# Altium schematic sheet PAGE4 - SFP.SchDoc
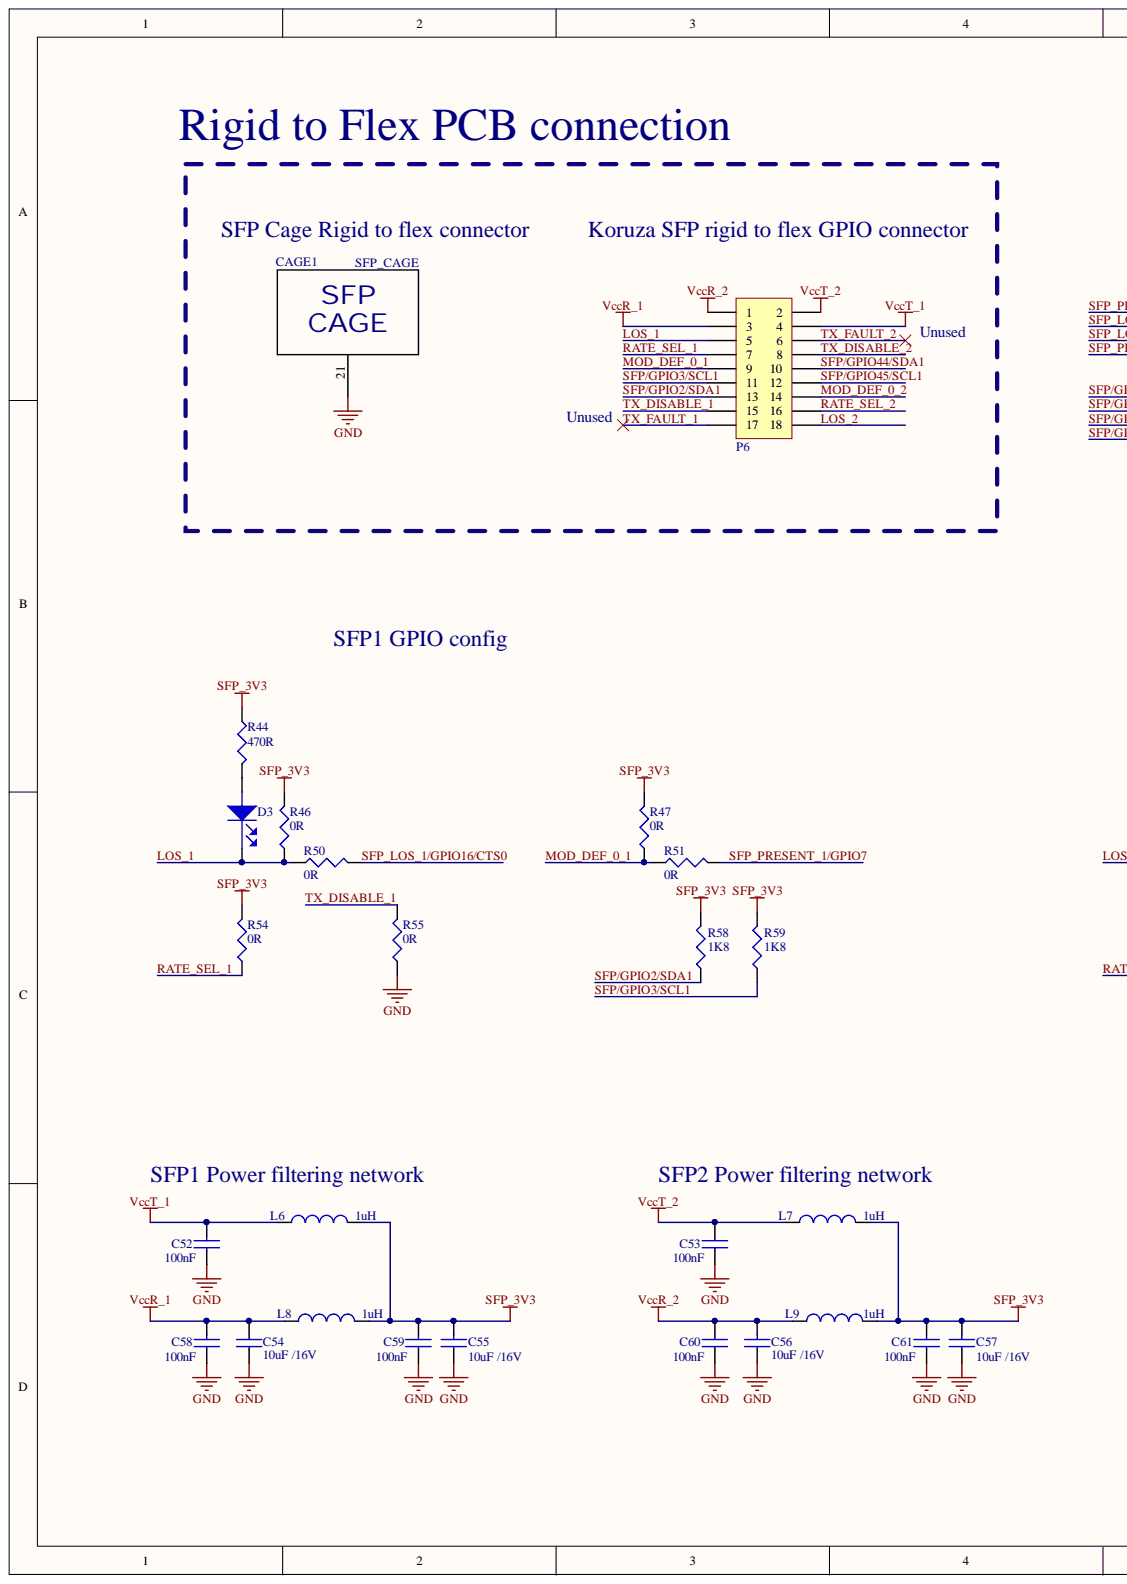
[diagram p0: PAGE4 - SFP.SchDoc, left]
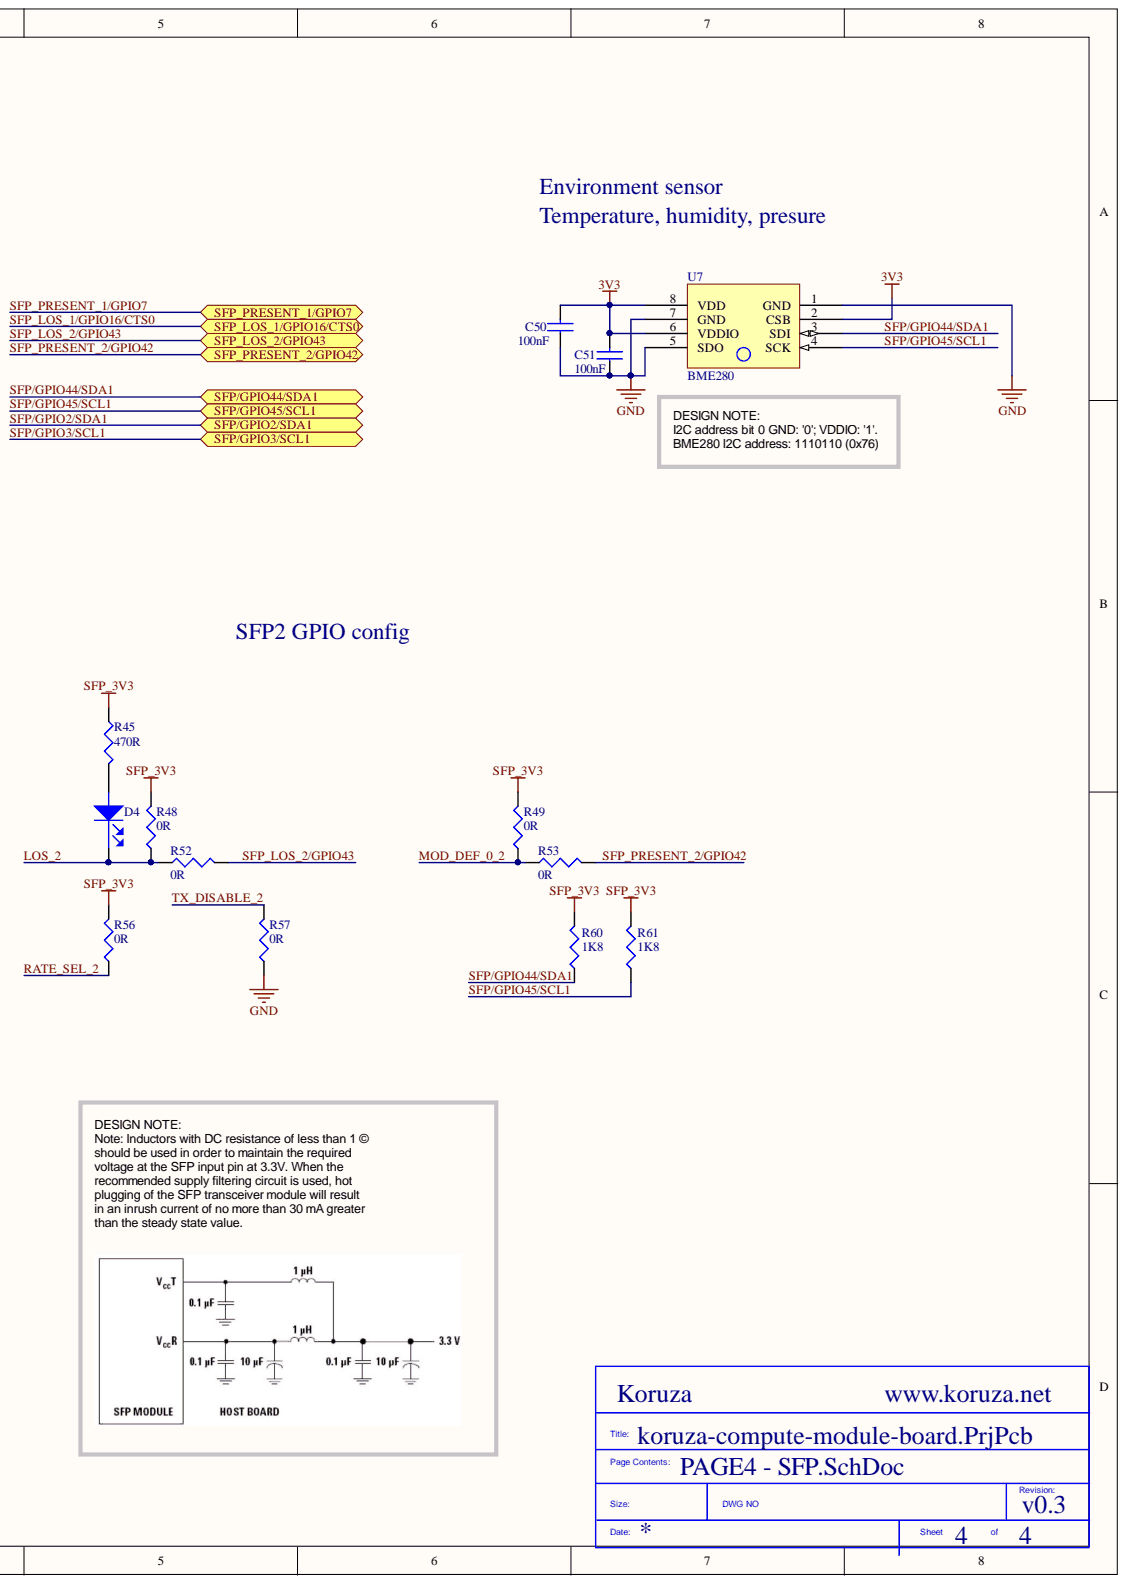
[diagram p1: PAGE4 - SFP.SchDoc, right]

## Parts
C50  100nF  [CAP0603]
C51  100nF  [CAP0603]
C52  100nF  [CAP0603]
C53  100nF  [CAP0603]
C54  10uF /16V  [CAP0603]
C55  10uF /16V  [CAP0603]
C56  10uF /16V  [CAP0603]
C57  10uF /16V  [CAP0603]
C58  100nF  [CAP0603]
C59  100nF  [CAP0603]
C60  100nF  [CAP0603]
C61  100nF  [CAP0603]
CAGE1  SFP_CAGE  Connector Type: SFP  [PCB_SFP_CAGE_FOOTPRINT]
D3  LED RED  [LED0603]  (value not drawn)
D4  LED RED  [LED0603]  (value not drawn)
L6  1uH  [CAP0805]
L7  1uH  [CAP0805]
L8  1uH  [CAP0805]
L9  1uH  [CAP0805]
P6  HED2X9  [HDR2X9]  (value not drawn)
R44  470R  [RES0603]
R45  470R  [RES0603]
R46  0R  [RES0603]
R47  0R  [RES0603]
R48  0R  [RES0603]
R49  0R  [RES0603]
R50  0R  [RES0603]
R51  0R  [RES0603]
R52  0R  [RES0603]
R53  0R  [RES0603]
R54  0R  [RES0603]
R55  0R  [RES0603]
R56  0R  [RES0603]
R57  0R  [RES0603]
R58  1K8  [RES0603]
R59  1K8  [RES0603]
R60  1K8  [RES0603]
R61  1K8  [RES0603]
U7  BME280  [CAVITY MEMS]

## Ports
SFP/GPIO2/SDA1, SFP/GPIO3/SCL1, SFP/GPIO44/SDA1, SFP/GPIO45/SCL1, SFP_LOS_1/GPIO16/CTS0, SFP_LOS_2/GPIO43, SFP_PRESENT_1/GPIO7, SFP_PRESENT_2/GPIO42

## Nets
3V3: C50.2 C51.2 U7.2(CSB) U7.6(VDDIO) U7.8(VDD)
GND: C50.1 C51.1 C52.1 C53.1 C54.1 C55.1 C56.1 C57.1 C58.1 C59.1 C60.1 C61.1 CAGE1.21 R55.1 R57.1 U7.1(GND) U7.5(SDO) U7.7(GND)
SFP_3V3: C55.2 C57.2 C59.2 C61.2 L6.2 L7.2 L8.2 L9.2 R44.1 R45.1 R46.2 R47.2 R48.2 R49.2 R54.2 R56.2 R58.2 R59.2 R60.2 R61.2
VCCR_1: C54.2 C58.2 L8.1 P6.3
VCCR_2: C56.2 C60.2 L9.1 P6.1
VCCT_1: C52.2 L6.1 P6.4
VCCT_2: C53.2 L7.1 P6.2
LOS_1: D3.1 P6.5 R46.1 R50.1
LOS_2: D4.1 P6.18 R48.1 R52.1
MOD_DEF_0_1: P6.9 R47.1 R51.1
MOD_DEF_0_2: P6.14 R49.1 R53.1
RATE_SEL_1: P6.7 R54.1
RATE_SEL_2: P6.16 R56.1
SFP/GPIO2/SDA1: P6.13 R58.1 ; port SFP/GPIO2/SDA1
SFP/GPIO3/SCL1: P6.11 R59.1 ; port SFP/GPIO3/SCL1
SFP/GPIO44/SDA1: P6.10 R60.1 U7.3(SDI) ; port SFP/GPIO44/SDA1
SFP/GPIO45/SCL1: P6.12 R61.1 U7.4(SCK) ; port SFP/GPIO45/SCL1
SFP_LOS_1/GPIO16/CTS0: R50.2 ; port SFP_LOS_1/GPIO16/CTS0
SFP_LOS_2/GPIO43: R52.2 ; port SFP_LOS_2/GPIO43
SFP_PRESENT_1/GPIO7: R51.2 ; port SFP_PRESENT_1/GPIO7
SFP_PRESENT_2/GPIO42: R53.2 ; port SFP_PRESENT_2/GPIO42
TX_DISABLE_1: P6.15 R55.2
TX_DISABLE_2: P6.8 R57.2
TX_FAULT_1: P6.17
TX_FAULT_2: P6.6
NetD3_2: D3.2 R44.2
NetD4_2: D4.2 R45.2

## Library data of the parts
C50-C53 C58-C61 = 100nF: MURATA - GRM188R71C104KA01J - CAP, MLCC, X7R, 0.1UF, 16V, 0603; Capacitance: 0.1; Capacitance Tolerance: ± 10%; Ceramic Capacitor Case: 0603 [1608 Metric]; Dielectric Characteristic: X7; Product Range: GRM Series; SVHC: No SVHC (20-Jun-2016; Voltage Rating: 16; Automotive Qualification Standard: -; Component Kind: Standard; ComponentLink1Description: http://www.farnell.com/datasheets/2189124.pdf; ComponentLink1URL: http://www.farnell.com/datasheets/2189124.pdf; Library Name: SCHLib.SchLib; Manufacturer: MURATA; Manufacturer Part Number: GRM188R71C104KA01J; MSL: MSL 1 - Unlimited; Operating Temperature Max: 125; Operating Temperature Min: -55; Packaging: Cut Tape; Pricing 1: 10=0.0145, 100=0.0126, 500=0.0081, 2500=0.0059, 5000=0.0052, 10000=0.0046, 50000=0.0036, 100000=0.0035 (GBP); RoHS: YES; Stock 1: 18297; Supplier 1: Farnell; Supplier Part Number 1: 2688519
C54-C57 = GRM188R61C106MA73D: MURATA - GRM188R61C106MA73D - CAP, MLCC, X5R, 10UF, 16V, 0603; Capacitance: 10; Capacitance Tolerance: ± 20%; Ceramic Capacitor Case: 0603 [1608 Metric]; Dielectric Characteristic: X5; Product Range: GRM Series; SVHC: No SVHC (17-Dec-2015)<!--FANDB--><div class="features-and-benefits-container"><div class="features-and-benefits-description"><span class="features-benefits-value">The Murata GRM series capacitors are Multilayer Ceramic Chip Capacitors (MLCC) used for general purpose applications. These MLCC capacitors are classified into temperature compensating and high dielectric constant types depending on the change in electrostatic capacitance due to change in temperature. The temperature compensating capacitors feature a small rate of change in electrostatic capacitance as the temperature changes and are used for applications such as filters and high frequency circuit matching. The high dielectric constant capacitors use materials with a high dielectric constant and feature a large electrostatic capacitance. Typical applications are power supply decoupling and smoothing circuits.</span></div><div class="features-and-benefits-list"><ul class="features-and-benefits-list-list"><li class="features-and-benefits-list-item">Large capacity and small size in a multilayer structure</li><li class="features-and-benefits-list-item">Capacitance value ranging from 0.1pF to 220?F</li><li class="features-and-benefits-list-item">Tin plated external electrodes, excellent solderability</li><li class="features-and-benefits-list-item">Provides good high frequency characteristics</li><li class="features-and-benefits-list-item">Excellent pulse response</li><li class="features-and-benefits-list-item">High reliability</li><li class="features-and-benefits-list-item">Capacitor size varies from 0.4×0.2mm to 5.7×5.0mm</li><li class="features-and-benefits-list-item">Rated voltage of 2.5VDC to 3.15; Voltage Rating: 16; Automotive Qualification Standard: -; Component Kind: Standard; ComponentLink1Description: http://www.farnell.com/datasheets/2048226.pdf; ComponentLink1URL: http://www.farnell.com/datasheets/2048226.pdf; Library Name: SCHLib.SchLib; Manufacturer: MURATA; Manufacturer Part Number: GRM188R61C106MA73D; MSL: -; Operating Temperature Max: 85; Operating Temperature Min: -55; Packaging: Cut Tape; Pricing 1: 10=0.181, 100=0.103, 500=0.0863, 1000=0.0778, 2000=0.0677 (GBP); RoHS: YES; Stock 1: 21735; Supplier 1: Farnell; Supplier Part Number 1: 2426958
CAGE1 = SFP_CAGE_FOOTPRINT: TE CONNECTIVITY - 2007194-1 - CAGE, 1X1, SFP+, PCI APPLICATIONS; Accessory Type: Connector Cage / Frame; Connector Mounting: PCB Mount; Connector Mounting Orientation: PC Board; Contact Termination: Solder; For Use With: Small Form-Factor Pluggable Connectors; Component Kind: Standard; ComponentLink1Description: http://www.farnell.com/datasheets/1654545.pdf; ComponentLink1URL: http://www.farnell.com/datasheets/1654545.pdf; ComponentLink2Description: http://www.farnell.com/cad/1661409.pdf; ComponentLink2URL: http://www.farnell.com/cad/1661409.pdf; ComponentLink3Description: http://www.farnell.com/datasheets/1922296.pdf; ComponentLink3URL: http://www.farnell.com/datasheets/1922296.pdf; Library Name: SCHLib.SchLib; Manufacturer: TE CONNECTIVITY; Manufacturer Part Number: 2007194-1; Material: Nickel Silver<!--FANDB--><div class="features-and-benefits-container"><div class="features-and-benefits-description"><span class="features-benefits-value">The 2007194-1 is a 1-port Cage Assembly with external springs and solder through-hole termination. It is made with nickel silver single cage. The SFP+ pluggable cage designed to support data rate of 16Gbps. This SFP+ pluggable cage has one degree mounting angle to meet the requirements of SFF-8075 for PCI card applications and support belly-to-belly mount applications.</span></div><div class="features-and-benefits-applications"><ul class="features-and-benefits-value"><li class="features-and-benefits-list-item">Industrial</li></ul></div></div>; Pricing 1: 1=4.6, 5=4.41, 10=4.22, 25=4.03, 50=3.5, 200=3.18, 500=2.86, 2000=2.8 (GBP); Product Range: -; RoHS: YES; Stock 1: 26; Supplier 1: Farnell; Supplier Part Number 1: 1755779
D3 D4 = HSMS-C190: BROADCOM LIMITED - HSMS-C190 - LED, RED, 10MCD, 626NM; Forward Current If: 20; Forward Voltage: 2.1; LED Mounting: SMD; Lens Shape: Rectangular; Luminous Intensity: 10; SVHC: No SVHC (17-Dec-2015)<!--FANDB--><div class="features-and-benefits-container"><div class="features-and-benefits-description"><span class="features-benefits-value">The HSMS-C190 is a HER SMD Chip LED with untinted/diffused lens, made of GaP. It is designed in an industry standard package 1.6 x 0.8mm footprint with 0.8mm profile. It is compatible with IR reflow solder processes. The small size and wide viewing angle make this LED prime choices for backlighting applications and front panel illumination especially where space is a premium.</span></div><div class="features-and-benefits-list"><ul class="features-and-benefits-list-list"><li class="features-and-benefits-list-item">Small size</li><li class="features-and-benefits-list-item">Industry standard footprint</li><li class="features-and-benefits-list-item">Compatible with IR solder</li><li class="features-and-benefits-list-item">Diffused optics</li><li class="features-and-benefits-list-item">-40 to 85; Viewing Angle: 170°; Wavelength Typ: 626; Automotive Qualification Standard: -; Bulb Size: -; Component Kind: Standard; ComponentLink1Description: http://www.farnell.com/datasheets/313031.pdf; ComponentLink1URL: http://www.farnell.com/datasheets/313031.pdf; ComponentLink2Description: http://www.farnell.com/datasheets/1681524.pdf; ComponentLink2URL: http://www.farnell.com/datasheets/1681524.pdf; ComponentLink3Description: http://www.farnell.com/datasheets/2165483.pdf; ComponentLink3URL: http://www.farnell.com/datasheets/2165483.pdf; LED Colour: Red; Library Name: SCHLib.SchLib; Manufacturer: BROADCOM LIMITED; Manufacturer Part Number: HSMS-C190; MSL: -; Packaging: Cut Tape; Pricing 1: 1=0.361, 10=0.256, 50=0.235, 100=0.106, 200=0.101 (GBP); Product Range: -; RoHS: YES; Stock 1: 7534; Supplier 1: Farnell; Supplier Part Number 1: 2497356
L6-L9 = 74479773210: WURTH ELEKTRONIK - 74479773210 - INDUCTOR, MULTILAYER, 1UH, SMD; DC Resistance Max: 0.18; Inductance: 1; Inductance Tolerance: ± 20%; Power Inductor Case: 2mm x 1.25mm x 0.5; Product Range: WE-PMI Series; RMS Current (Irms): 1.2; Saturation Current (Isat): 800; Component Kind: Standard; ComponentLink1Description: http://www.farnell.com/cad/2130715.pdf; ComponentLink1URL: http://www.farnell.com/cad/2130715.pdf; ComponentLink2Description: http://www.farnell.com/datasheets/1795751.pdf; ComponentLink2URL: http://www.farnell.com/datasheets/1795751.pdf; Inductor Construction: Multilayer; Library Name: SCHLib.SchLib; Manufacturer: WURTH ELEKTRONIK; Manufacturer Part Number: 74479773210; Packaging: Cut Tape; Pricing 1: 1=0.39, 50=0.34, 100=0.29, 250=0.25 (GBP); RoHS: YES; Stock 1: 46; Supplier 1: Farnell; Supplier Part Number 1: 2400015
P6 = MHDR2X9: Header, 9-Pin, Dual row; Component Kind: Standard; LatestRevisionDate: 17-Jul-2002; LatestRevisionNote: Re-released for DXP Platform.; Library Name: SCHLib.SchLib; Publisher: Altium Limited
R44 R45 = MCMR06X471 JTL: MULTICOMP - MCMR06X471 JTL - RES, CERAMIC, 470R, 5%, 0.1W, 0603; Component Kind: Standard; ComponentLink1Description: http://www.farnell.com/datasheets/1716707.pdf; ComponentLink1URL: http://www.farnell.com/datasheets/1716707.pdf; Library Name: SCHLib.SchLib; Manufacturer: MULTICOMP; Manufacturer Part Number: MCMR06X471 JTL; Pricing 1: 10=0.0089, 100=0.0076, 500=0.0063, 1000=0.0049, 2500=0.0033, 5000=0.0024, 25000=0.0016, 50000=0.0013 (GBP); RoHS: Y-EX; Stock 1: 3786; Supplier 1: Farnell; Supplier Part Number 1: 2073513
R46-R57 = CR0603-J/-000ELF: BOURNS - CR0603-J/-000ELF - RES, THICK FILM, 0R, 5%, 0.1W, 0603; Power Rating: 100; Product Range: CR Series; Resistance: 0; Resistor Case Style: 0603 [1608 Metric]; Resistor Mounting: Surface Mount Device<!--FANDB--><div class="features-and-benefits-container"><div class="features-and-benefits-description"><span class="features-benefits-value">The Bourns CR series are standard thick film chip resistors. These resistors provide flexibility of performance, space saving and low cost. The Resistance range includes E96 and E24 decade values from 1ohm to 10Mohm.</span></div><div class="features-and-benefits-list"><ul class="features-and-benefits-list-list"><li class="features-and-benefits-list-item">0201, 0402, 0603, 0805, 1206, 1210, 2010, 2512 standard case sizes available</li><li class="features-and-benefits-list-item">±1% and ±5% tolerance</li><li class="features-and-benefits-list-item">±100ppm/°C, ±200ppm/°C and ±250ppm/°C TCR values available</li><li class="features-and-benefits-list-item">Power rating selectable for 50mW, 63mW, 0.1W, 0.125W, 0.25W, 0.5W and 1W based on ordering</li><li class="features-and-benefits-list-item">Jumpers are available for all size</li><li class="features-and-benefits-list-item">3 layer termination process with nickel barrier prevents leaching & provides excellent solderability</li><li class="features-and-benefits-list-item">Suitable for all type of soldering processes</li><li class="features-and-benefits-list-item">Tight dimensional tolerances</li><li class="features-and-benefits-list-item">Maximum working voltage depends on case size</li><li class="features-and-benefits-list-item">Operating temperature of -55°C to +125°C(CR0201) and -55°C to +155; SVHC: No SVHC (17-Dec-2015); Voltage Rating: 50; Automotive Qualification Standard: -; Component Kind: Standard; ComponentLink1Description: http://www.bourns.com/engineering/CHPREZTR/cr0603.stp; ComponentLink1URL: http://www.bourns.com/engineering/CHPREZTR/cr0603.stp; ComponentLink2Description: http://www.farnell.com/datasheets/2178762.pdf; ComponentLink2URL: http://www.farnell.com/datasheets/2178762.pdf; Library Name: SCHLib.SchLib; Manufacturer: BOURNS; Manufacturer Part Number: CR0603-J/-000ELF; MSL: MSL 1 - Unlimited; Packaging: Cut Tape; Pricing 1: 10=0.0146, 250=0.0097, 1000=0.0073, 5000=0.0049, 12500=0.0042 (GBP); Resistance Tolerance: ± 5%; Resistor Element Material: Thick Film; RoHS: YES; Stock 1: 58200; Supplier 1: Farnell; Supplier Part Number 1: 2008343; Temperature Coefficient: -
R58-R61 = CRCW06031K80FKEA: VISHAY - CRCW06031K80FKEA - RES, THICK FILM, 1K8, 1%, 0.1W, 0603; Component Kind: Standard; ComponentLink1Description: http://www.farnell.com/datasheets/2111203.pdf; ComponentLink1URL: http://www.farnell.com/datasheets/2111203.pdf; ComponentLink2Description: http://www.farnell.com/cad/1552026.zip; ComponentLink2URL: http://www.farnell.com/cad/1552026.zip; Library Name: SCHLib.SchLib; Manufacturer: VISHAY; Manufacturer Part Number: CRCW06031K80FKEA; Pricing 1: 10=0.0105, 100=0.0094, 500=0.0055, 1000=0.005, 2500=0.0038 (GBP); RoHS: Y-EX; Stock 1: 21649; Supplier 1: Farnell; Supplier Part Number 1: 1652854
U7 = BME280: Board Mount Humidity Sensors; Brand: Bosch Sensortec; Interface Type: I2C, SPI; Operating Supply Current: 0.5 uA; Operating Supply Voltage: 1.8 V; Output Type: Digital; RH Accuracy: 3 %; RH Range: 0 % to 100 %; Supply Voltage - Max: 3.6 VDC; Supply Voltage - Min: 1.7 VDC; Category: Board Mount Humidity Sensors; Component Kind: Standard; ComponentLink1Description: http://www.mouser.com/ds/2/783/BST-BME280_DS001-11-844833.pdf; ComponentLink1URL: http://www.mouser.com/ds/2/783/BST-BME280_DS001-11-844833.pdf; Library Name: SCHLib.SchLib; Manufacturer: Bosch Sensortec; Manufacturer Part Number: BME280; Maximum Operating Temperature: + 85 C; Minimum Operating Temperature: - 40 C; Minimum Order Quantity: 1; Mounting Style: SMD/SMT; Package/Case: LGA-8; Packaging: Reel; Pricing 1: 1=6.85, 10=6.16, 25=5.06, 100=4.38, 250=4.24, 500=4.10, 1000=3.83, 2500=3.53, 5000=3.09, 10000=3.09 (EUR); Product: Board Mount; Reeling: True; RoHS: RoHS Compliant; RoHS - Mouser: Y; Standard Pack Qty: 10000; Stock 1: 21206; Supplier 1: Mouser; Supplier Part Number 1: 262-BME280
